# Altium schematic sheet PIXRACER-POWER-V1-R15.SchDoc(PIXRACER-POWER-V1-R15)
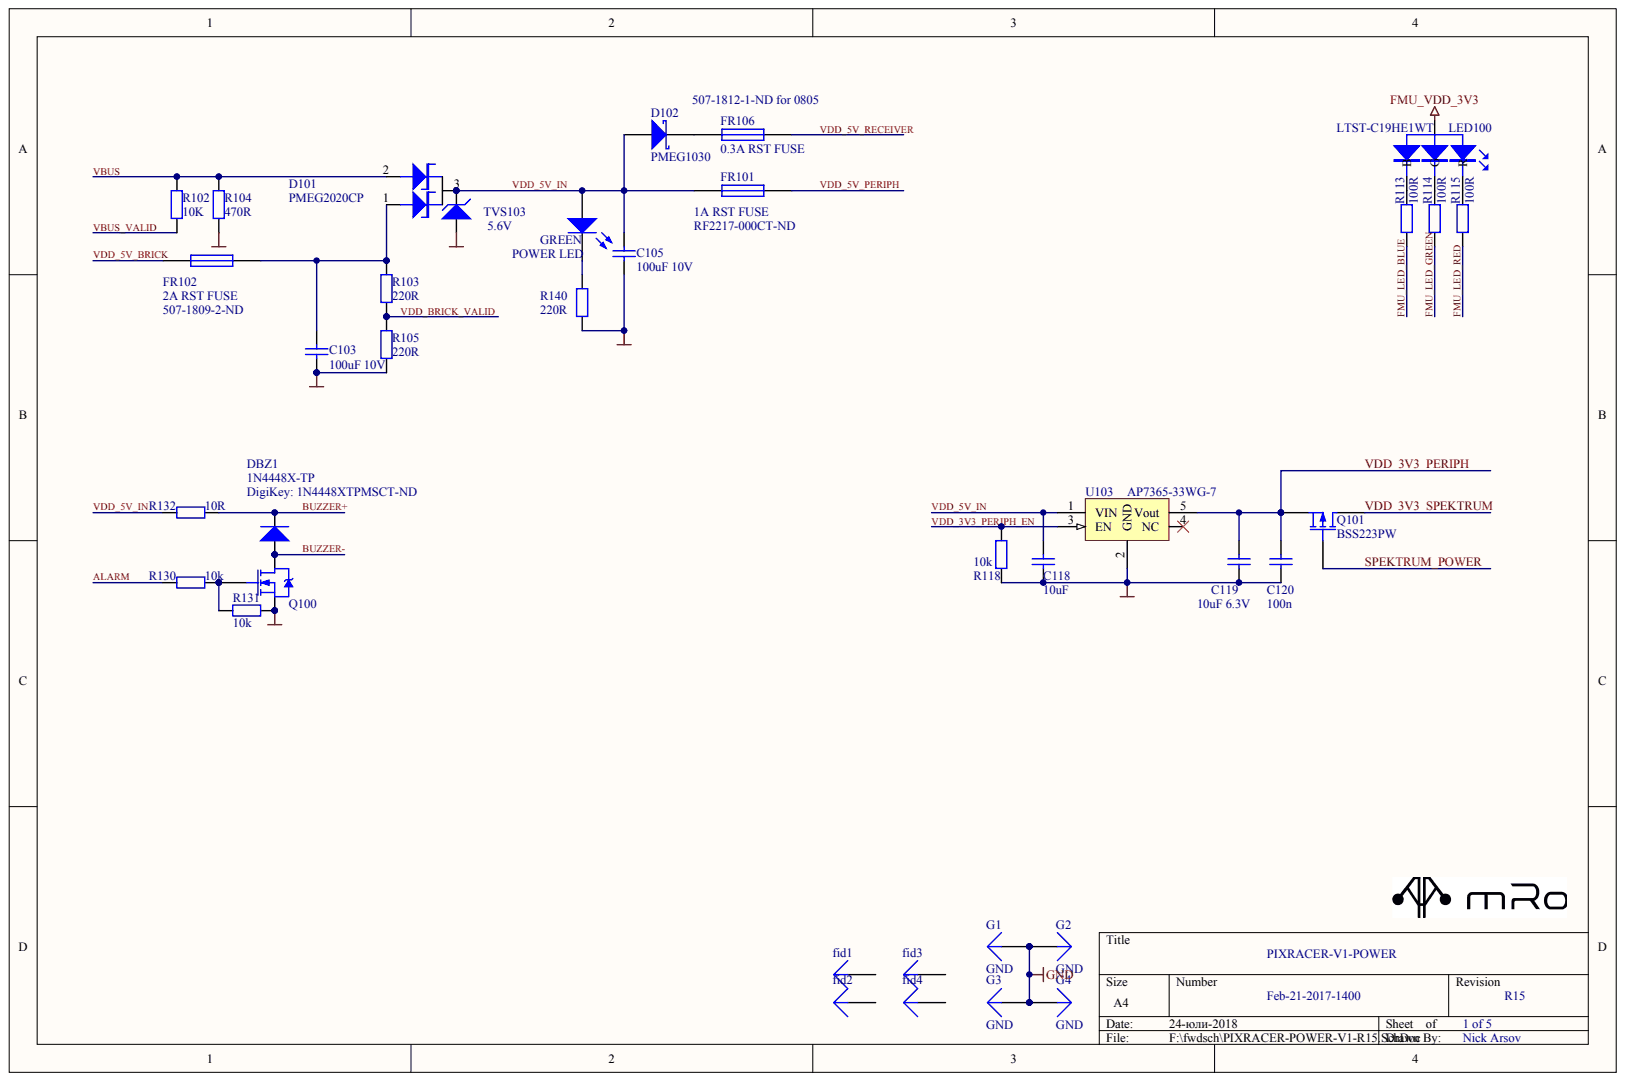
[diagram p0: PIXRACER-POWER-V1-R15.SchDoc]

## Parts
C103  100uF 10V
C105  100uF 10V
C118  10uF
C119  10uF 6.3V
C120  100n
D101  PMEG2020CP
D102  PMEG1030
DBZ1  1N4448X-TP  DigiKey: 1N4448XTPMSCT-ND
fid1  (no value)
fid2  (no value)
fid3  (no value)
fid4  (no value)
FR101  1A RST FUSE  DigiKey: RF2217-000CT-ND
FR102  2A RST FUSE
FR106  0.3A RST FUSE
G1  GND
G2  GND
G3  GND
G4  GND
LED100  LTST-C19HE1WT
POWER_LED  GREEN
Q100  DMG1012UW  (value not drawn)
Q101  BSS223PW
R102  10K
R103  220R
R104  470R
R105  220R
R113  100R
R114  100R
R115  100R
R118  10k
R130  10k
R131  10k
R132  10R
R140  220R
TVS103  5.6V
U103  AP7365-33WG-7

## Nets
FMU_VDD_3V3: LED100.4
GND: C103.1 C105.1 C118.1 C119.1 C120.1 G1.1 G2.1 G3.1 G4.1 Q100.2 R104.1 R105.2 R118.1 R131.2 R140.1 TVS103.1 U103.2(GND)
ALARM: R130.2
BUZZER+: DBZ1.2 R132.1
BUZZER-: DBZ1.1 Q100.1
FMU_LED_BLUE: R113.1
FMU_LED_GREEN: R114.1
FMU_LED_RED: R115.1
SPEKTRUM_POWER: Q101.3
VBUS: D101.2 R102.2 R104.2
VBUS_VALID: R102.1
VDD_3V3_PERIPH: C119.2 C120.2 Q101.2 U103.5(Vout)
VDD_3V3_PERIPH_EN: R118.2 U103.3(EN)
VDD_3V3_SPEKTRUM: Q101.1
VDD_5V_BRICK: FR102.1
VDD_5V_IN: C105.2 C118.2 D101.3 D102.1 FR101.1 POWER_LED.1 R132.2 TVS103.2 U103.1(VIN)
VDD_5V_PERIPH: FR101.2
VDD_5V_RECEIVER: FR106.2
VDD_BRICK_VALID: R103.2 R105.1
NetC103_2: C103.2 D101.1 FR102.2 R103.1
NetD102_2: D102.2 FR106.1
NetLED100_1: LED100.1(R) R115.2
NetLED100_2: LED100.2(G) R114.2
NetLED100_3: LED100.3(B) R113.2
NetPOWER LED_2: POWER_LED.2 R140.2
NetQ100_3: Q100.3 R130.1 R131.1

Not connected: fid1 1; fid2 1; fid3 1; fid4 1; U103 4(NC)

## Library data of the parts
C103 C105 C118-C120 = (no symbol name): Value: 100pF; Published: 8-Jun-2000; LatestRevisionDate: 17-Jul-2002; LatestRevisionNote: Re-released for DXP Platform.; PackageReference: RAD-0.3; PackageDescription: Radial Cap, Thru-Hole; 2 Leads; 0.3 in Pin Spacing; Publisher: Altium Limited
Q100 = (no symbol name): Note: Pin 2 is electrically connected to pin 4.; Published: 8-Jun-2000; LatestRevisionDate: 17-Jul-2002; LatestRevisionNote: Re-released for DXP Platform.; DatasheetDocument: PD-9.814; PackageReference: TO-220AB; Code_JEDEC: TO-220-AB; ComponentLink1Description: Manufacturer Link; ComponentLink1URL: http://www.irf.com/; Publisher: Altium Limited
R102-R105 R113-R115 R118 R130-R132 R140 = (no symbol name): Value: 1K; Published: 8-Jun-2000; LatestRevisionDate: 17-Jul-2002; LatestRevisionNote: Re-released for DXP Platform.; PackageReference: AXIAL-0.4; Publisher: Altium Limited
  R102-R105 R118 R130-R132 R140: PackageDescription: Axial Device, Thru-Hole; 2 Leads; 0.4 in Pin Spacing
D101 D102 DBZ1 fid1-fid4 FR101 FR102 FR106 G1-G4 LED100 POWER_LED Q101 TVS103 U103 = (no symbol name)
  D102: Published: 8-Jun-2000; LatestRevisionDate: 17-Jul-2002; LatestRevisionNote: Re-released for DXP Platform.; PackageReference: SMB; PackageDescription: DO-214-AA/SMB; 2 C-Bend Leads; Body 5.3 x 3.6 mm, inc. leads (LxW); Code_JEDEC: DO-214-AA
  DBZ1: Published: 8-Jun-2000; LatestRevisionDate: 17-Jul-2002; LatestRevisionNote: Re-released for DXP Platform.; PackageDocument: Sep-1996; PackageReference: SMC; Code_JEDEC: DO-214-AB
  fid1-fid4 G1-G4: LatestRevisionDate: 17-Jul-2002; LatestRevisionNote: Re-released for DXP Platform.
  FR101 FR106: Published: 8-Jun-2000; LatestRevisionDate: 17-Jul-2002; LatestRevisionNote: Re-released for DXP Platform.; PackageReference: PIN-W2/E2.8; PackageDescription: Thru-Hole; 2 Leads
  FR102: Published: 8-Jun-2000; LatestRevisionDate: 17-Jul-2002; LatestRevisionNote: Re-released for DXP Platform.; PackageReference: PIN-W2/E2.8; PackageDescription: Thru-Hole; 2 Leads; DigiKey: 507-1809-2-ND
  POWER_LED: Published: 8-Jun-2000; LatestRevisionDate: 08-Jul-2005; LatestRevisionNote: Mech Layer 1 removed.; PackageReference: LED-0; PackageDescription: LED; 2 Leads
  Q101: Published: 8-Jun-2000; LatestRevisionDate: 17-Jul-2002; LatestRevisionNote: Re-released for DXP Platform.; PackageVersion: 1995/1996; PackageReference: E3; PackageDescription: TO, Flat Index; 3 In-Line, Axial Leads; Body Dia. 4.6mm; Lead Dia. 0.5 mm (max)
  TVS103: Published: 8-Jun-2000; LatestRevisionDate: 17-Jul-2002; LatestRevisionNote: Re-released for DXP Platform.; PackageReference: DIODE-0.7; PackageDescription: Diode, Thru-Hole; 2 Leads; 0.7 in Pin Spacing
  D102 DBZ1 fid1-fid4 FR101 FR102 FR106 G1-G4 POWER_LED Q101 TVS103: Publisher: Altium Limited
